# Altium schematic sheet 2.POWER.SchDoc(2.POWER)
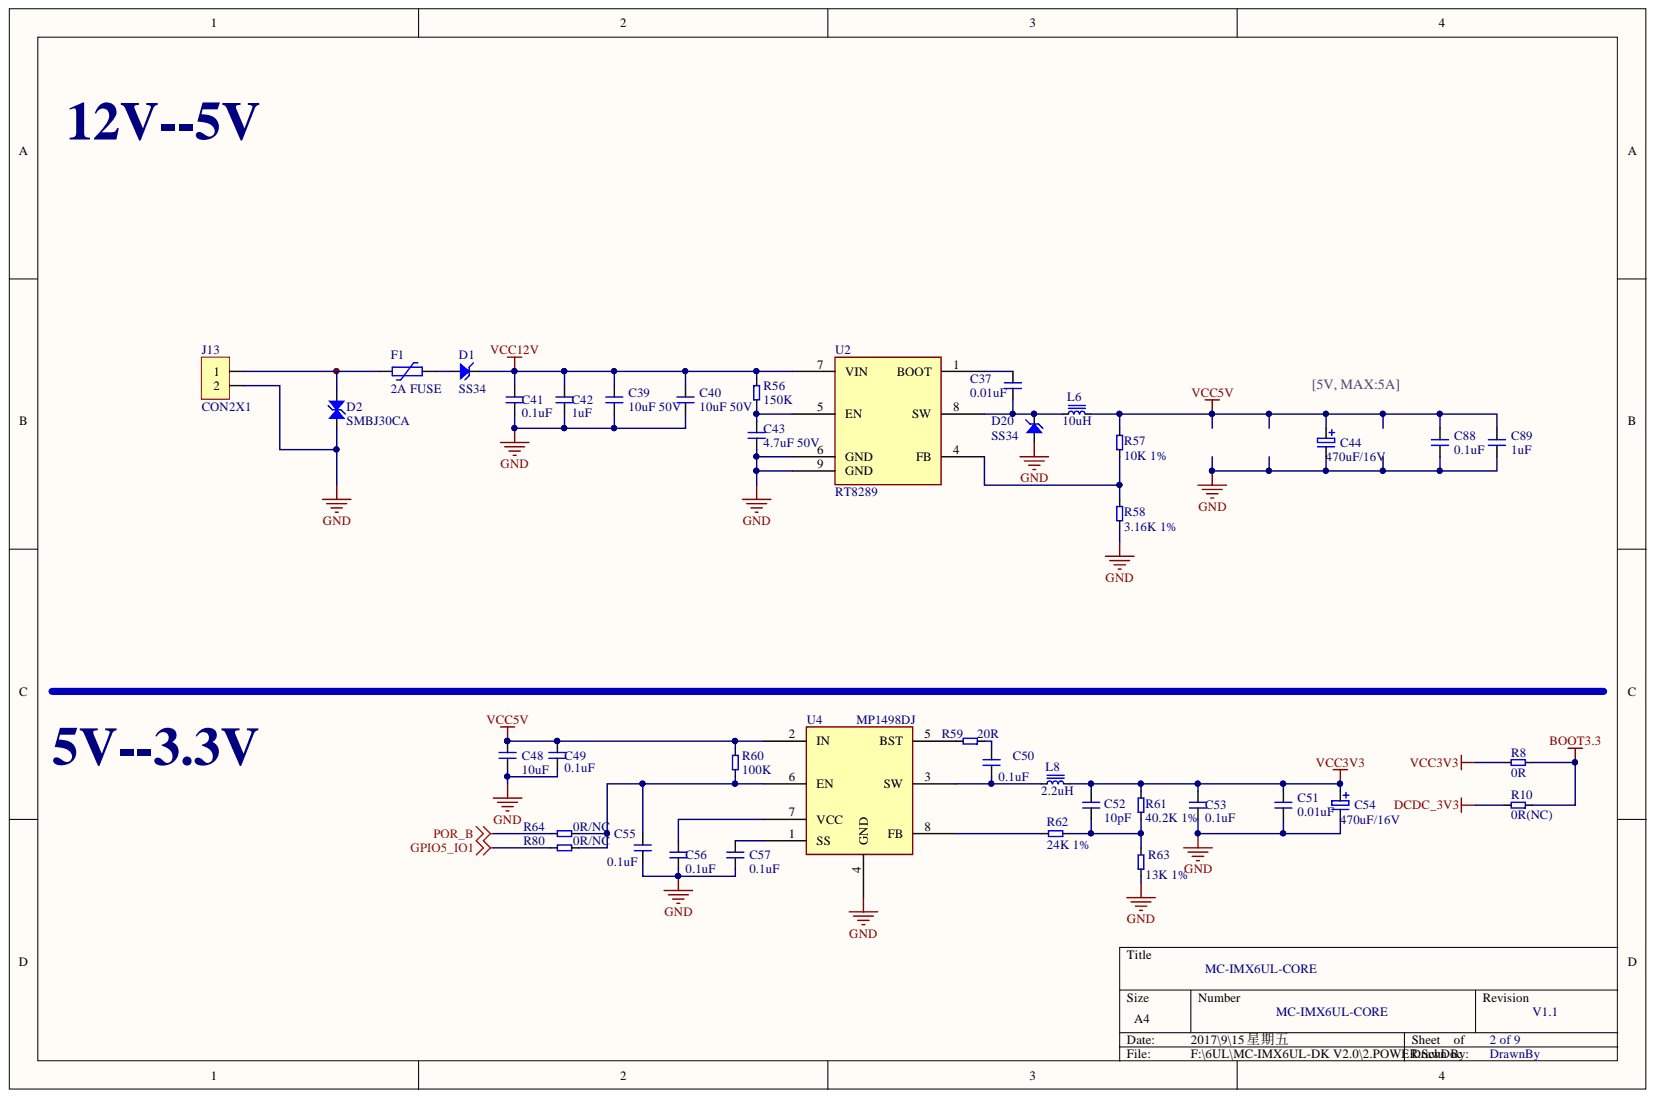
[diagram p0: 2.POWER.SchDoc]

## Parts
C37  0.01uF  [0603C]
C39  10uF 50V  [1206C]
C40  10uF 50V  [1206C]
C41  0.1uF  [0603C]
C42  1uF  [0805C]
C43  4.7uF 50V  [0805C]
C44  470uF/16V  [RAD-3/8]
C48  10uF  [0603C]
C49  0.1uF  [0603C]
C50  0.1uF  [0603C]
C51  0.01uF  [0603C]
C52  10pF  [0603C]
C53  0.1uF  [0603C]
C54  470uF/16V  [RAD-3/8]
C55  0.1uF  [0603C]
C56  0.1uF  [0603C]
C57  0.1uF  [0603C]
C88  0.1uF  [0603C]
C89  1uF  [0603C]
D1  SS34  [SMA]
D2  SMBJ30CA  [SMBJ]
D20  SS34  [SMA]
F1  2A FUSE  [1812F]
J13  CON2X1  [IDC2X1 3.81]
L6  10uH  [SDRH0632]
L8  2.2uH  [SDRH0420]
R8  0R  [0603R]
R10  0R(NC)  [0603R]
R56  150K  [0603R]
R57  10K 1%  [0603R]
R58  3.16K 1%  [0603R]
R59  20R  [0603R]
R60  100K  [0603R]
R61  40.2K 1%  [0603R]
R62  24K 1%  [0603R]
R63  13K 1%  [0603R]
R64  0R/NC  [0603R]
R80  0R/NC  [0603R]
U2  RT8289  [SOIC8EP-1.27]
U4  MP1498DJ  [TSOT23-8]

## Nets
BOOT3.3: R8.1 R10.1
DCDC_3V3: R10.2
GND: C39.2 C40.2 C41.2 C42.2 C43.2 C44.2 C48.2 C49.2 C51.2 C53.2 C54.2 C55.2 C56.2 C57.2 C88.2 C89.2 D2.1 D20.A J13.2 R58.1 R63.1 U2.6(GND) U2.9(GND) U4.4(GND)
GPIO5_IO1: R80.2
POR_B: R64.2
VCC3V3: C51.1 C52.2 C53.1 C54.1 L8.2 R8.2 R61.2
VCC5V: C44.1 C48.1 C49.1 C88.1 C89.1 L6.2 R57.2 R60.2 U4.2(IN)
VCC12V: C39.1 C40.1 C41.1 C42.1 D1.K R56.2 U2.7(VIN)
NetC37_1: C37.1 U2.1(BOOT)
NetC37_2: C37.2 D20.K L6.1 U2.8(SW)
NetC43_1: C43.1 R56.1 U2.5(EN)
NetC50_1: C50.1 R59.1
NetC50_2: C50.2 L8.1 U4.3(SW)
NetC52_1: C52.1 R61.1 R62.1 R63.2
NetC55_1: C55.1 R60.1 R64.1 R80.1 U4.6(EN)
NetC56_1: C56.1 U4.7(VCC)
NetC57_1: C57.1 U4.1(SS)
NetD1_A: D1.A F1.1
NetD2_2: D2.2 F1.2 J13.1
NetR57_1: R57.1 R58.2 U2.4(FB)
NetR59_2: R59.2 U4.5(BST)
NetR62_2: R62.2 U4.8(FB)

## Library data of the parts
C37 C39-C43 C48-C53 C55-C57 C88 C89 = 电容: Component Kind: Standard; Description: 电容; Library Name: LDT-SCH.SCHLIB
C44 C54 = 直插电解电容: Component Kind: Standard; Description: 直插电解电容
D1 D20 = SS24: 二极管; Component Kind: Standard; Library Name: LDT-SCH.SCHLIB
D2 = P6KE: Component Kind: Standard; Library Name: LDT-SCH.SCHLIB
F1 = FUSE: 保险丝; Part Field 1: 台湾聚鼎; Component Kind: Standard
L6 L8 = 功率电感: Component Kind: Standard; Library Name: LDT-SCH.SCHLIB
R8 R10 R56-R64 R80 = 电阻: Component Kind: Standard; Description: 电阻
  R56-R58 R60-R64 R80: Library Name: LDT-SCH.SCHLIB
U2 = RT8289: RT8289GSP; Component Kind: Standard; Library Name: LDT-SCH.SCHLIB
U4 = MP1498DJ: MP1498DJ 2A; Component Kind: Standard; Library Name: LDT-SCH.SCHLIB
J13 = CON2X1: Component Kind: Standard; Library Name: LDT-SCH.SCHLIB
